# Altium schematic sheet Sheet1.SchDoc(Sheet1)
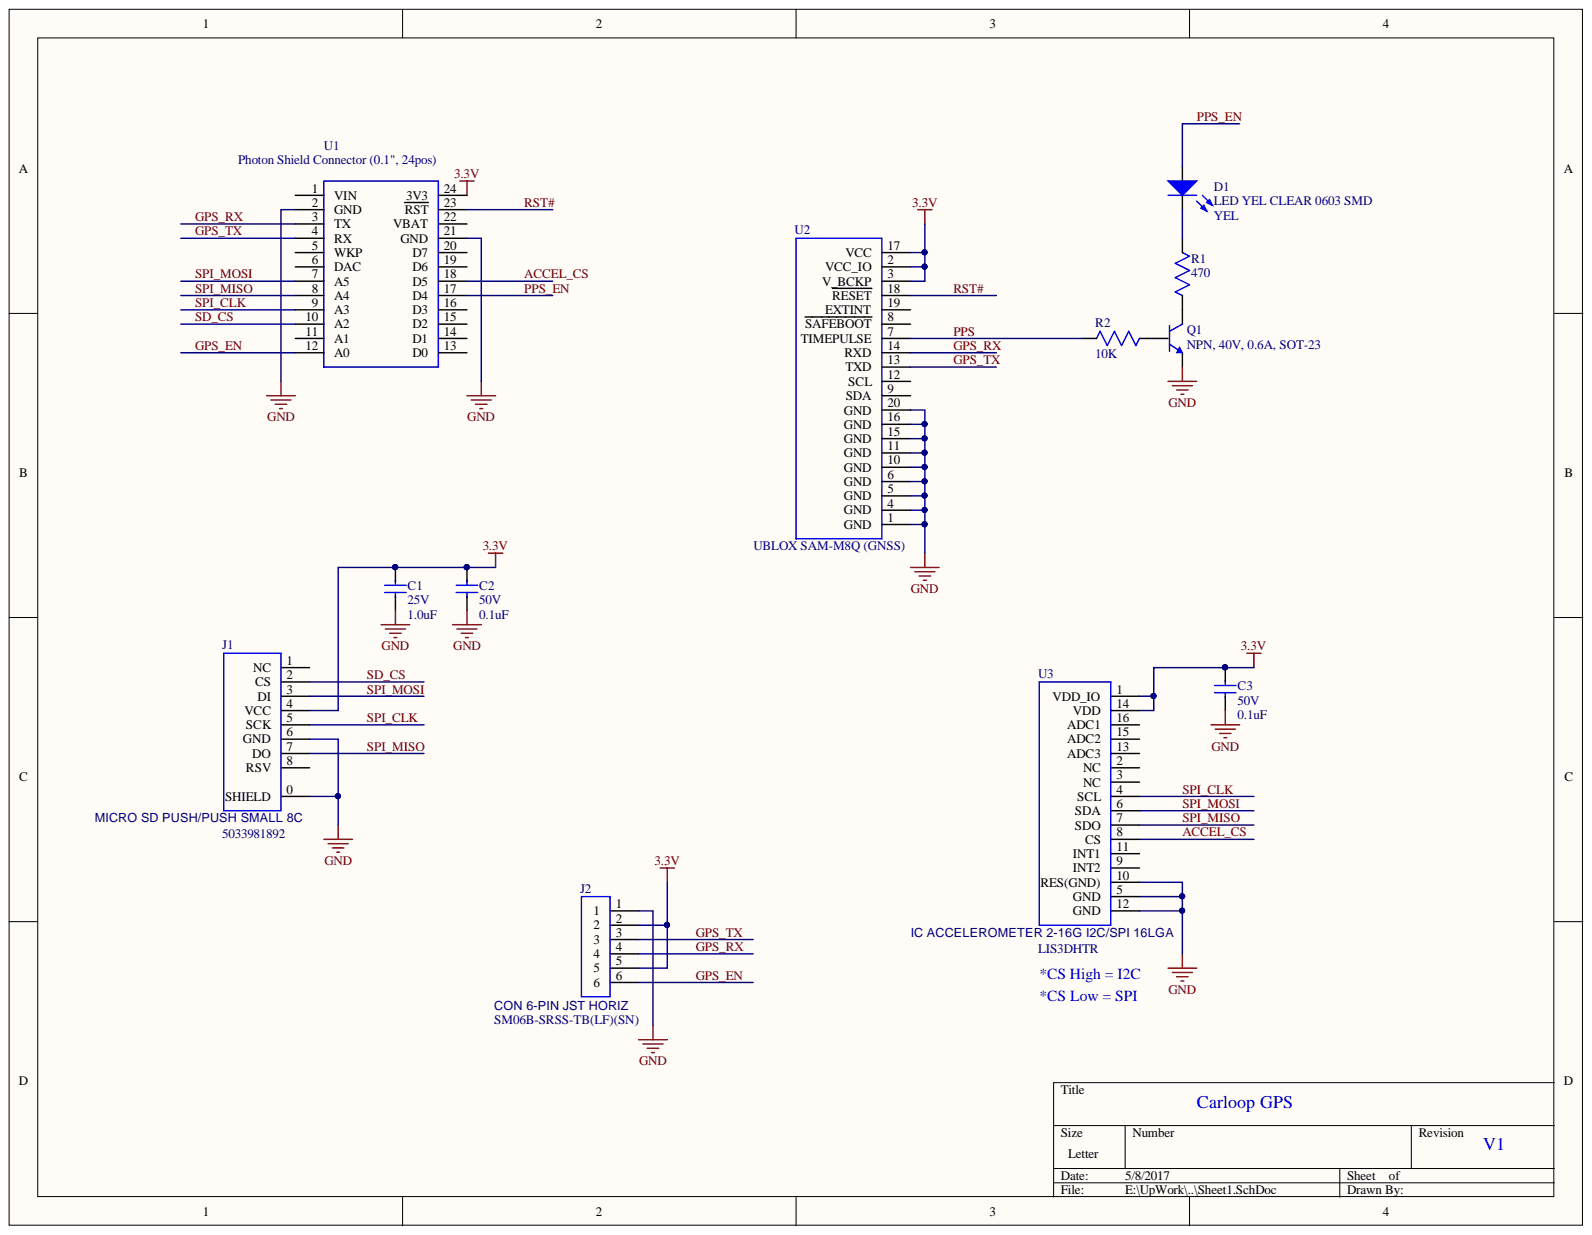
[diagram p0: Sheet1.SchDoc]

## Parts
C1  value 1.0uF  Voltage: 25V  [0805]  (hidden comment: CAP CER 1.0UF 25V XR7 0805)
C2  value 0.1uF  Voltage: 50V  [0805]  (hidden comment: CAP CER 0.1UF 50V XR7 0805)
C3  value 0.1uF  Voltage: 50V  [0805]  (hidden comment: CAP CER 0.1UF 50V XR7 0805)
D1  LED YEL CLEAR 0603 SMD  value YEL  [LED0603_ALPHA_YEL]
J1  MICRO SD PUSH/PUSH SMALL 8C  Part Number: 5033981892  [Micro SD Card Socket - Molex 5033981892]
J2  CON 6-PIN JST HORIZ  Part Number: SM06B-SRSS-TB(LF)(SN)  [JST - SM06B-SRSS-TB]
Q1  NPN 40V 0.6A SOT-23  value NPN, 40V, 0.6A, SOT-23  [SOT23_C-BE]
R1  value 470  [0805]  (hidden comment: RES 470 1% 0805)
R2  value 10K  [0805]  (hidden comment: RES 10K 1% 0805)
U1  Photon Shield Connector (0.1", 24pos)  [PHOTON SHIELD CONN]
U2  UBLOX SAM-M8Q (GNSS)  Part Number: SAM-M8Q  Manufacturer: UBLOX  [UBLOX SAM-M8Q]
U3  IC ACCELEROMETER 2-16G I2C/SPI 16LGA  Part Number: LIS3DHTR  [LGA-16(3X3X1mm)]

## Nets
3.3V: C1.1 C2.1 C3.1 J1.4(VCC) J2.2 J2.5 U1.24(3V3) U2.2(VCC_IO) U2.3(V_BCKP) U2.17(VCC) U3.1(VDD_IO) U3.14(VDD)
GND: C1.2 C2.2 C3.2 J1.0(SHIELD) J1.6(GND) J2.1 Q1.E U1.2(GND) U1.21(GND) U2.1(GND) U2.4(GND) U2.5(GND) U2.6(GND) U2.10(GND) U2.11(GND) U2.15(GND) U2.16(GND) U2.20(GND) U3.5(GND) U3.10(RES(GND)) U3.12(GND)
ACCEL_CS: U1.18(D5) U3.8(CS)
GPS_EN: J2.6 U1.12(A0)
GPS_RX: J2.4 U1.3(TX) U2.14(RXD)
GPS_TX: J2.3 U1.4(RX) U2.13(TXD)
PPS: R2.1 U2.7(TIMEPULSE)
PPS_EN: D1.A U1.17(D4)
RST#: U1.23(RST) U2.18(RESET)
SD_CS: J1.2(CS) U1.10(A2)
SPI_CLK: J1.5(SCK) U1.9(A3) U3.4(SCL)
SPI_MISO: J1.7(DO) U1.8(A4) U3.7(SDO)
SPI_MOSI: J1.3(DI) U1.7(A5) U3.6(SDA)
NetD1_K: D1.K R1.2
NetQ1_B: Q1.B R2.2
NetQ1_C: Q1.C R1.1

Not connected: J1 1(NC) 8(RSV); U1 1(VIN) 5(WKP) 6(DAC) 11(A1) 13(D0) 14(D1) 15(D2) 16(D3) 19(D6) 20(D7) 22(VBAT); U2 8(SAFEBOOT) 9(SDA) 12(SCL) 19(EXTINT); U3 2(NC) 3(NC) 9(INT2) 11(INT1) 13(ADC3) 15(ADC2) 16(ADC1)

## Library data of the parts
C1 = CAP CER 1.0UF 25V XR7 0805: CAP CER 1.0UF 25V X7R 10% 0805; Part Number: C2012X7R1E105K; Manufacturer: TDK; LHI p/n: 10E-220-008; Tolerance: 10%; Component Kind: Standard; ComponentHeight: 0.028; Library Name: UW Schematic Conponents.SchLib
C2 C3 = CAP CER 0.1UF 50V XR7 0805: CAP CER 0.1UF 50V X7R 10% 0805; Part Number: CC0805KRX7R9BB104; Manufacturer: Yageo; LHI p/n: 10E-220-002; Tolerance: 10%; Component Kind: Standard; ComponentHeight: 0.022; Library Name: UW Schematic Conponents.SchLib
D1 = LED YEL CLEAR 0603 SMD: Part Number: LTST-C191KSKT; Manufacturer: Lite-On Inc.; Description: LED YEL CLEAR 0603 SMD; Component Kind: Standard; Library Name: UW Schematic Conponents.SchLib
J1 = MICRO SD PUSH/PUSH SMALL 8C: Manufacturer: Molex, LLC; Description: MICRO SD PUSH/PUSH SMALL 8C; Component Kind: Standard; Library Name: UW Schematic Conponents.SchLib
J2 = CON 6-PIN JST HORIZ: Manufacturer: JST Sales America Inc.; Description: CON 6-PIN JST HORIZ; Component Kind: Standard; Library Name: UW Schematic Conponents.SchLib
Q1 = NPN 40V 0.6A SOT-23: Part Number: MMBT2222A; Manufacturer: Fairchild; LHI p/n: 10E-214-001; Description: NPN 40V 0.6A SOT-23; Component Kind: Standard; Library Name: UW Schematic Conponents.SchLib
R1 = RES 470 1% 0805: Part Number: RC0805FR-07470RL; Manufacturer: YAGEO; LHI p/n: 10E-210-056; Description: RES 470 1% 0805; Component Kind: Standard; ComponentHeight: 0.022; Library Name: UW Schematic Conponents.SchLib
R2 = RES 10K 1% 0805: Part Number: RC0805FR-0710KL; Manufacturer: Yageo; LHI p/n: 10E-210-003; Description: RES 10K 1% 0805; Component Kind: Standard; ComponentHeight: 0.022; Library Name: UW Schematic Conponents.SchLib
U1 = Photon Shield Connector (0.1", 24pos): Part Number: 61302411121; Manufacturer: Wurth Electronics Inc.; Description: Photon Shield Connector (0.1", 24pos); Component Kind: Standard; Library Name: UW Schematic Conponents.SchLib
U3 = IC ACCELEROMETER 2-16G I2C/SPI 16LGA: Manufacturer: STMicroelectronic; Description: IC ACCELEROMETER 2-16G I2C/SPI 16LGA; Component Kind: Standard; Library Name: UW Schematic Conponents.SchLib
U2 = UBLOX SAM-M8Q (GNSS): Description: UBLOX SAM-M8Q (GNSS); Component Kind: Standard; Library Name: UW Schematic Conponents.SchLib
